# Altium schematic sheet MQ4.SchDoc
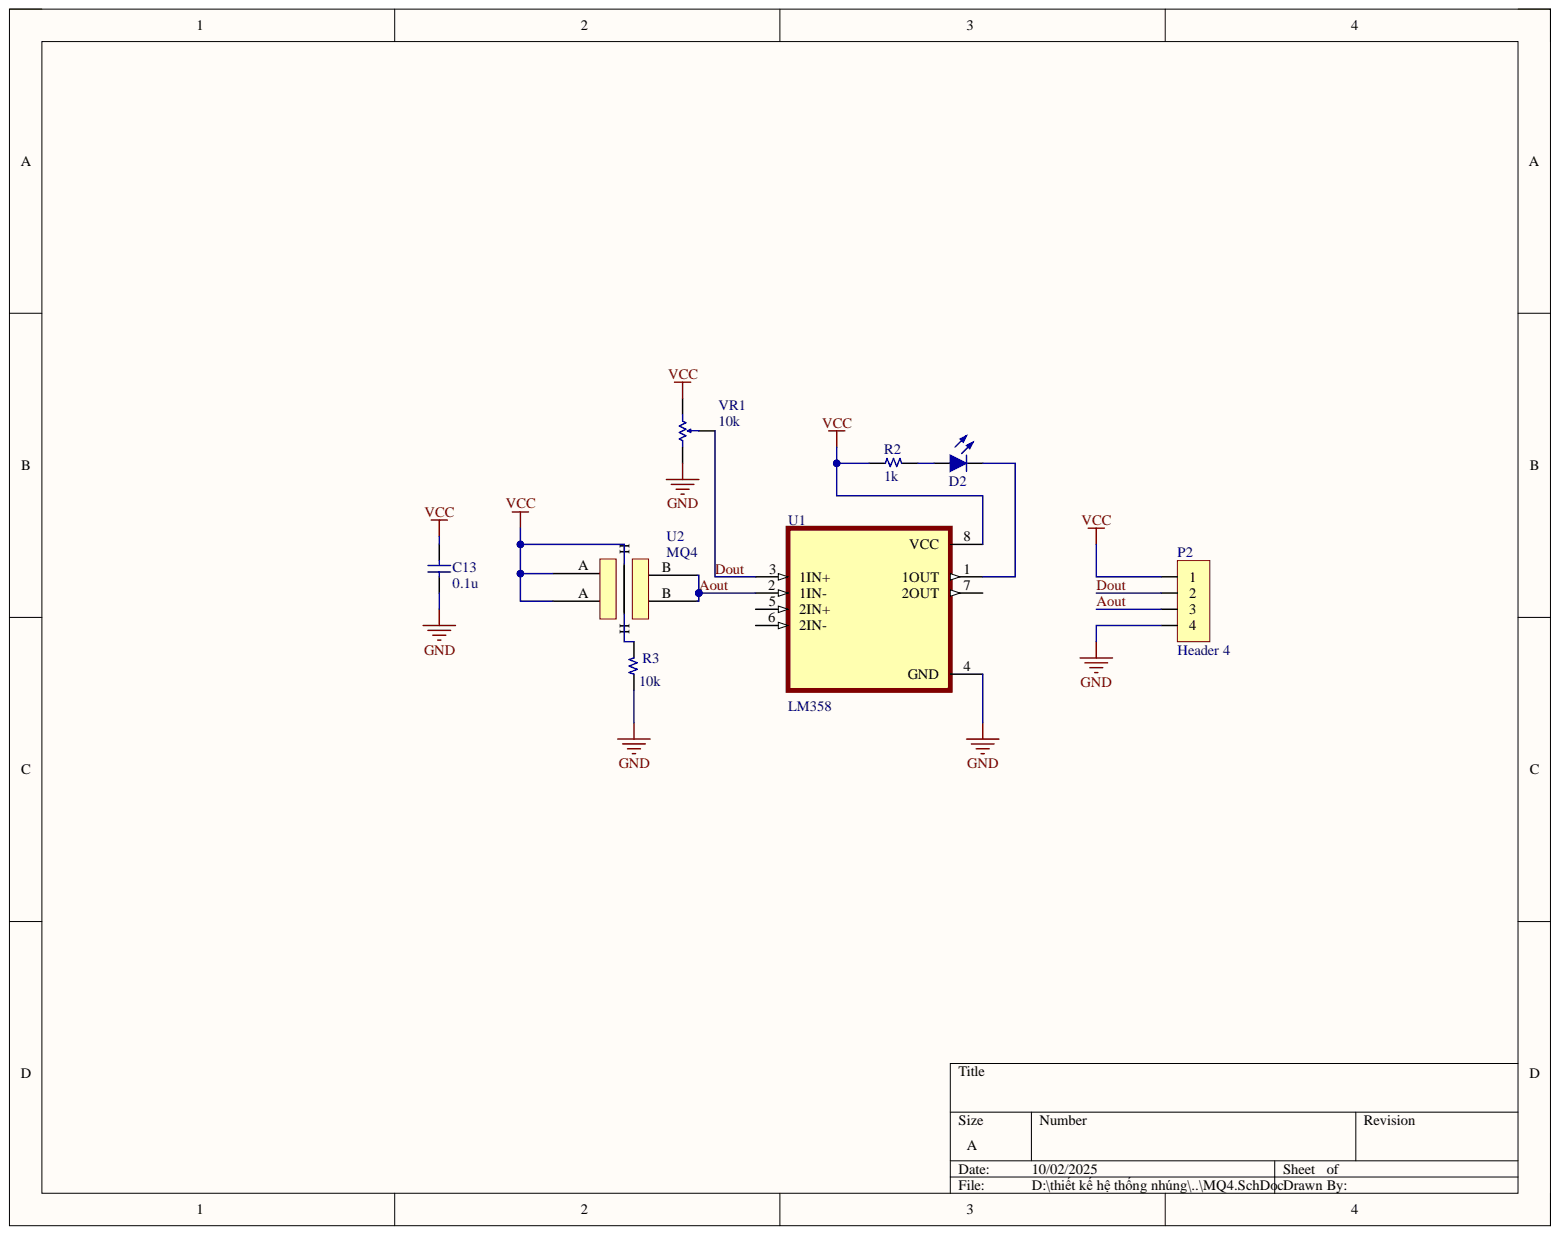
[diagram p0: MQ4.SchDoc]

## Parts
C13  0.1u  [C 0603]
D2  Led  [Led 0603 - red]  (value not drawn)
P2  Header 4  [HDR/Header/4]
R2  1k  [R 0603]
R3  10k  [R 0603]
U1  LM358  [SOIC127P599X175-8N]
U2  MQ4  [MQ4]
VR1  10k  [R VAR B]

## Nets
GND: C13.2 P2.4 R3.1 U1.4(GND) VR1.3
VCC: C13.1 P2.1 R2.1 U1.8(VCC) U2.A U2.H VR1.1
Aout: P2.3 U1.2(1IN-) U2.B
Dout: P2.2 U1.3(1IN+) VR1.2
NetD2_1: D2.1 R2.2
NetD2_2: D2.2 U1.1(1OUT)
NetR3_2: R3.2 U2.H

Not connected: U1 5(2IN+) 6(2IN-) 7(2OUT)

## Library data of the parts
C13 = Capacitor NP: Capacitor non-pol; Component Kind: Standard; Library Name: chipfc_libs.SchLib
D2 = Led: Typical LED; Component Kind: Standard; Library Name: led.SchLib
P2 = Header 4: Header, 4-Pin; Component Kind: Standard; Library Name: connectors.SchLib
R2 R3 = Resistor: Component Kind: Standard; Description: Resistor; Library Name: chipfc_libs.SchLib
U1 = LM358ADRG4: Ã‡ift, 30-V, 700-kHz, 3-mV ofset voltajlÄ± operasyonel amplifikatÃ¶r; MF: Texas Instruments; Check_prices: https://www.snapeda.com/parts/LM358ADRG4/Texas+Instruments/view-part/?ref=eda; Component Kind: Standard; Library Name: LM358ADRG4.IntLib; MP: LM358ADRG4; Package: SOIC-8 Texas Instruments; SnapEDA_Link: https://www.snapeda.com/parts/LM358ADRG4/Texas+Instruments/view-part/?ref=snap
VR1 = Resistor Variable: Component Kind: Standard; Description: Resistor Variable; Library Name: chipfc_libs.SchLib
U2 = MQ4: Component Kind: Standard; Library Name: MQ4.SchLib; Supplier 1: DigiKey; Supplier Part Number 1: 1738-1108-ND
